# Altium schematic sheet RF_AD9361.SchDoc
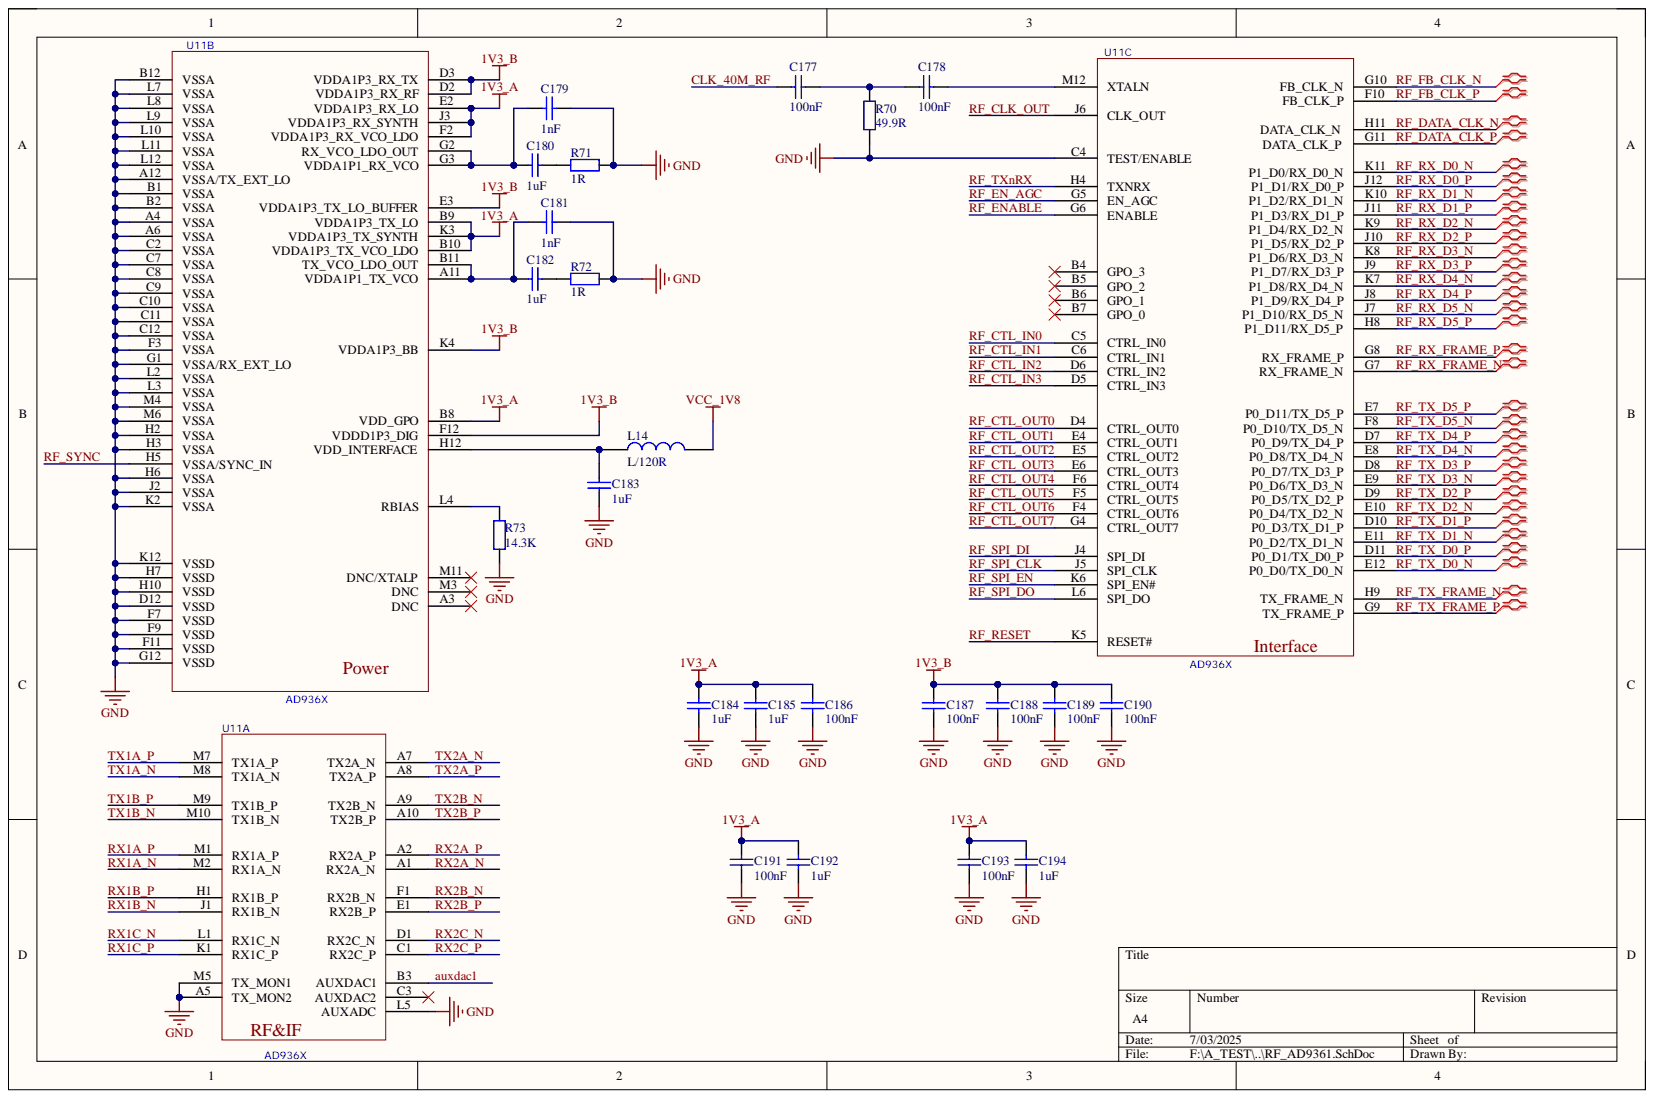
[diagram p0: RF_AD9361.SchDoc]

## Parts
C177  100nF  [C 0402_L]
C178  100nF  [C 0402_L]
C179  1nF  [C 0402_L]
C180  1uF  [C 0402_L]
C181  1nF  [C 0402_L]
C182  1uF  [C 0402_L]
C183  1uF  [C 0402_L]
C184  1uF  [C 0402_L]
C185  1uF  [C 0402_L]
C186  100nF  [C 0402_L]
C187  100nF  [C 0402_L]
C188  100nF  [C 0402_L]
C189  100nF  [C 0402_L]
C190  100nF  [C 0402_L]
C191  100nF  [C 0402_L]
C192  1uF  [C 0402_L]
C193  100nF  [C 0402_L]
C194  1uF  [C 0402_L]
L14  L/120R  [L 0402]
R70  49.9R  [R 0402_L]
R71  1R  [R 0402_L]
R72  1R  [R 0402_L]
R73  14.3K  [R 0402_L]
U11  AD936X  [BGA144C80P12X12_1000X1000X170]  (parts A,B,C)

## Nets
1V3_A: C184.2 C185.2 C186.2 C191.2 C192.2 C193.2 C194.2 U11.B8(VDD_GPO) U11.B9(VDDA1P3_TX_LO) U11.B10(VDDA1P3_TX_VCO_LDO) U11.E2(VDDA1P3_RX_LO) U11.F2(VDDA1P3_RX_VCO_LDO) U11.J3(VDDA1P3_RX_SYNTH) U11.K3(VDDA1P3_TX_SYNTH)
1V3_B: C187.2 C188.2 C189.2 C190.2 U11.D2(VDDA1P3_RX_RF) U11.D3(VDDA1P3_RX_TX) U11.E3(VDDA1P3_TX_LO_BUFFER) U11.F12(VDDD1P3_DIG) U11.K4(VDDA1P3_BB)
GND: C179.2 C181.2 C183.1 C184.1 C185.1 C186.1 C187.1 C188.1 C189.1 C190.1 C191.1 C192.1 C193.1 C194.1 R70.1 R71.2 R72.2 R73.1 U11.A4(VSSA) U11.A5(TX_MON2) U11.A6(VSSA) U11.A12(VSSA/TX_EXT_LO) U11.B1(VSSA) U11.B2(VSSA) U11.B12(VSSA) U11.C2(VSSA) U11.C4(TEST/ENABLE) U11.C7(VSSA) U11.C8(VSSA) U11.C9(VSSA) U11.C10(VSSA) U11.C11(VSSA) U11.C12(VSSA) U11.D12(VSSD) U11.F3(VSSA) U11.F7(VSSD) U11.F9(VSSD) U11.F11(VSSD) U11.G1(VSSA/RX_EXT_LO) U11.G12(VSSD) U11.H2(VSSA) U11.H3(VSSA) U11.H6(VSSA) U11.H7(VSSD) U11.H10(VSSD) U11.J2(VSSA) U11.K2(VSSA) U11.K12(VSSD) U11.L2(VSSA) U11.L3(VSSA) U11.L5(AUXADC) U11.L7(VSSA) U11.L8(VSSA) U11.L9(VSSA) U11.L10(VSSA) U11.L11(VSSA) U11.L12(VSSA) U11.M4(VSSA) U11.M5(TX_MON1) U11.M6(VSSA)
VCC_1V8: L14.2
auxdac1: U11.B3(AUXDAC1)
CLK_40M_RF: C177.1
RF_CLK_OUT: U11.J6(CLK_OUT)
RF_CTL_IN0: U11.C5(CTRL_IN0)
RF_CTL_IN1: U11.C6(CTRL_IN1)
RF_CTL_IN2: U11.D6(CTRL_IN2)
RF_CTL_IN3: U11.D5(CTRL_IN3)
RF_CTL_OUT0: U11.D4(CTRL_OUT0)
RF_CTL_OUT1: U11.E4(CTRL_OUT1)
RF_CTL_OUT2: U11.E5(CTRL_OUT2)
RF_CTL_OUT3: U11.E6(CTRL_OUT3)
RF_CTL_OUT4: U11.F6(CTRL_OUT4)
RF_CTL_OUT5: U11.F5(CTRL_OUT5)
RF_CTL_OUT6: U11.F4(CTRL_OUT6)
RF_CTL_OUT7: U11.G4(CTRL_OUT7)
RF_DATA_CLK_N: U11.H11(DATA_CLK_N)
RF_DATA_CLK_P: U11.G11(DATA_CLK_P)
RF_EN_AGC: U11.G5(EN_AGC)
RF_ENABLE: U11.G6(ENABLE)
RF_FB_CLK_N: U11.G10(FB_CLK_N)
RF_FB_CLK_P: U11.F10(FB_CLK_P)
RF_RESET: U11.K5(RESET#)
RF_RX_D0_N: U11.K11(P1_D0/RX_D0_N)
RF_RX_D0_P: U11.J12(P1_D1/RX_D0_P)
RF_RX_D1_N: U11.K10(P1_D2/RX_D1_N)
RF_RX_D1_P: U11.J11(P1_D3/RX_D1_P)
RF_RX_D2_N: U11.K9(P1_D4/RX_D2_N)
RF_RX_D2_P: U11.J10(P1_D5/RX_D2_P)
RF_RX_D3_N: U11.K8(P1_D6/RX_D3_N)
RF_RX_D3_P: U11.J9(P1_D7/RX_D3_P)
RF_RX_D4_N: U11.K7(P1_D8/RX_D4_N)
RF_RX_D4_P: U11.J8(P1_D9/RX_D4_P)
RF_RX_D5_N: U11.J7(P1_D10/RX_D5_N)
RF_RX_D5_P: U11.H8(P1_D11/RX_D5_P)
RF_RX_FRAME_N: U11.G7(RX_FRAME_N)
RF_RX_FRAME_P: U11.G8(RX_FRAME_P)
RF_SPI_CLK: U11.J5(SPI_CLK)
RF_SPI_DI: U11.J4(SPI_DI)
RF_SPI_DO: U11.L6(SPI_DO)
RF_SPI_EN: U11.K6(SPI_EN#)
RF_SYNC: U11.H5(VSSA/SYNC_IN)
RF_TX_D0_N: U11.E12(P0_D0/TX_D0_N)
RF_TX_D0_P: U11.D11(P0_D1/TX_D0_P)
RF_TX_D1_N: U11.E11(P0_D2/TX_D1_N)
RF_TX_D1_P: U11.D10(P0_D3/TX_D1_P)
RF_TX_D2_N: U11.E10(P0_D4/TX_D2_N)
RF_TX_D2_P: U11.D9(P0_D5/TX_D2_P)
RF_TX_D3_N: U11.E9(P0_D6/TX_D3_N)
RF_TX_D3_P: U11.D8(P0_D7/TX_D3_P)
RF_TX_D4_N: U11.E8(P0_D8/TX_D4_N)
RF_TX_D4_P: U11.D7(P0_D9/TX_D4_P)
RF_TX_D5_N: U11.F8(P0_D10/TX_D5_N)
RF_TX_D5_P: U11.E7(P0_D11/TX_D5_P)
RF_TX_FRAME_N: U11.H9(TX_FRAME_N)
RF_TX_FRAME_P: U11.G9(TX_FRAME_P)
RF_TXnRX: U11.H4(TXNRX)
RX1A_N: U11.M2(RX1A_N)
RX1A_P: U11.M1(RX1A_P)
RX1B_N: U11.J1(RX1B_N)
RX1B_P: U11.H1(RX1B_P)
RX1C_N: U11.L1(RX1C_N)
RX1C_P: U11.K1(RX1C_P)
RX2A_N: U11.A1(RX2A_N)
RX2A_P: U11.A2(RX2A_P)
RX2B_N: U11.F1(RX2B_N)
RX2B_P: U11.E1(RX2B_P)
RX2C_N: U11.D1(RX2C_N)
RX2C_P: U11.C1(RX2C_P)
TX1A_N: U11.M8(TX1A_N)
TX1A_P: U11.M7(TX1A_P)
TX1B_N: U11.M10(TX1B_N)
TX1B_P: U11.M9(TX1B_P)
TX2A_N: U11.A7(TX2A_N)
TX2A_P: U11.A8(TX2A_P)
TX2B_N: U11.A9(TX2B_N)
TX2B_P: U11.A10(TX2B_P)
NetC177_2: C177.2 C178.1 R70.2
NetC178_2: C178.2 U11.M12(XTALN)
NetC179_1: C179.1 C180.1 U11.G2(RX_VCO_LDO_OUT) U11.G3(VDDA1P1_RX_VCO)
NetC180_2: C180.2 R71.1
NetC181_1: C181.1 C182.1 U11.A11(VDDA1P1_TX_VCO) U11.B11(TX_VCO_LDO_OUT)
NetC182_2: C182.2 R72.1
NetC183_2: C183.2 L14.1 U11.H12(VDD_INTERFACE)
NetR73_2: R73.2 U11.L4(RBIAS)

Not connected: U11 A3(DNC) B4(GPO_3) B5(GPO_2) B6(GPO_1) B7(GPO_0) C3(AUXDAC2) M3(DNC) M11(DNC/XTALP)

## Library data of the parts
C177-C194 = Cap: 贴片电容; Component Kind: Standard; Library Name: A_Schlib.SchLib
  C177 C178 C186-C191 C193: value: 100nF
  C179 C181: value: 1nF
  C180 C182-C185 C192 C194: value: 1uF
L14 = 电感: 包含功率; Component Kind: Standard; Library Name: A_Schlib.SchLib
R70-R73 = Res: 通用; Component Kind: Standard; Library Name: A_Schlib.SchLib
  R70: value: 49.9R
  R71 R72: value: 1R
  R73: value: 14.3K
U11 = AD936X: 射频捷变收发器; Component Kind: Standard; Library Name: A_Schlib.SchLib
